# Altium schematic sheet Modules Schematic.SchDoc("Modules Schematic")
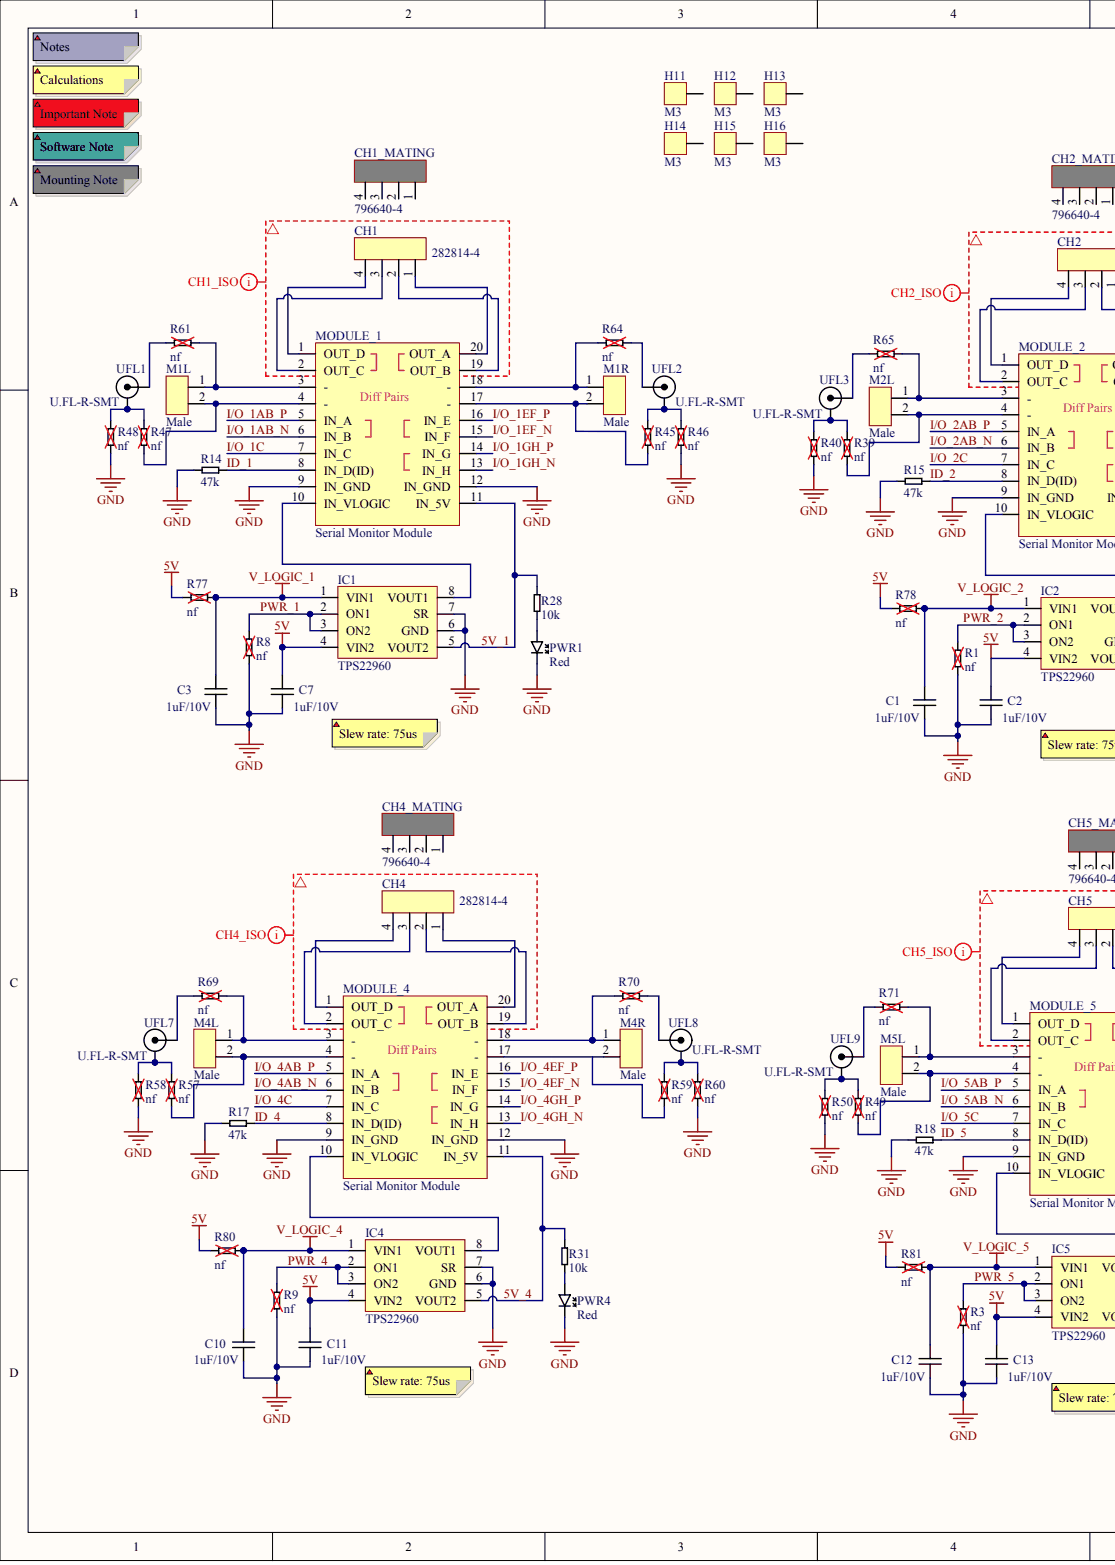
[diagram p0: Modules Schematic.SchDoc, left]
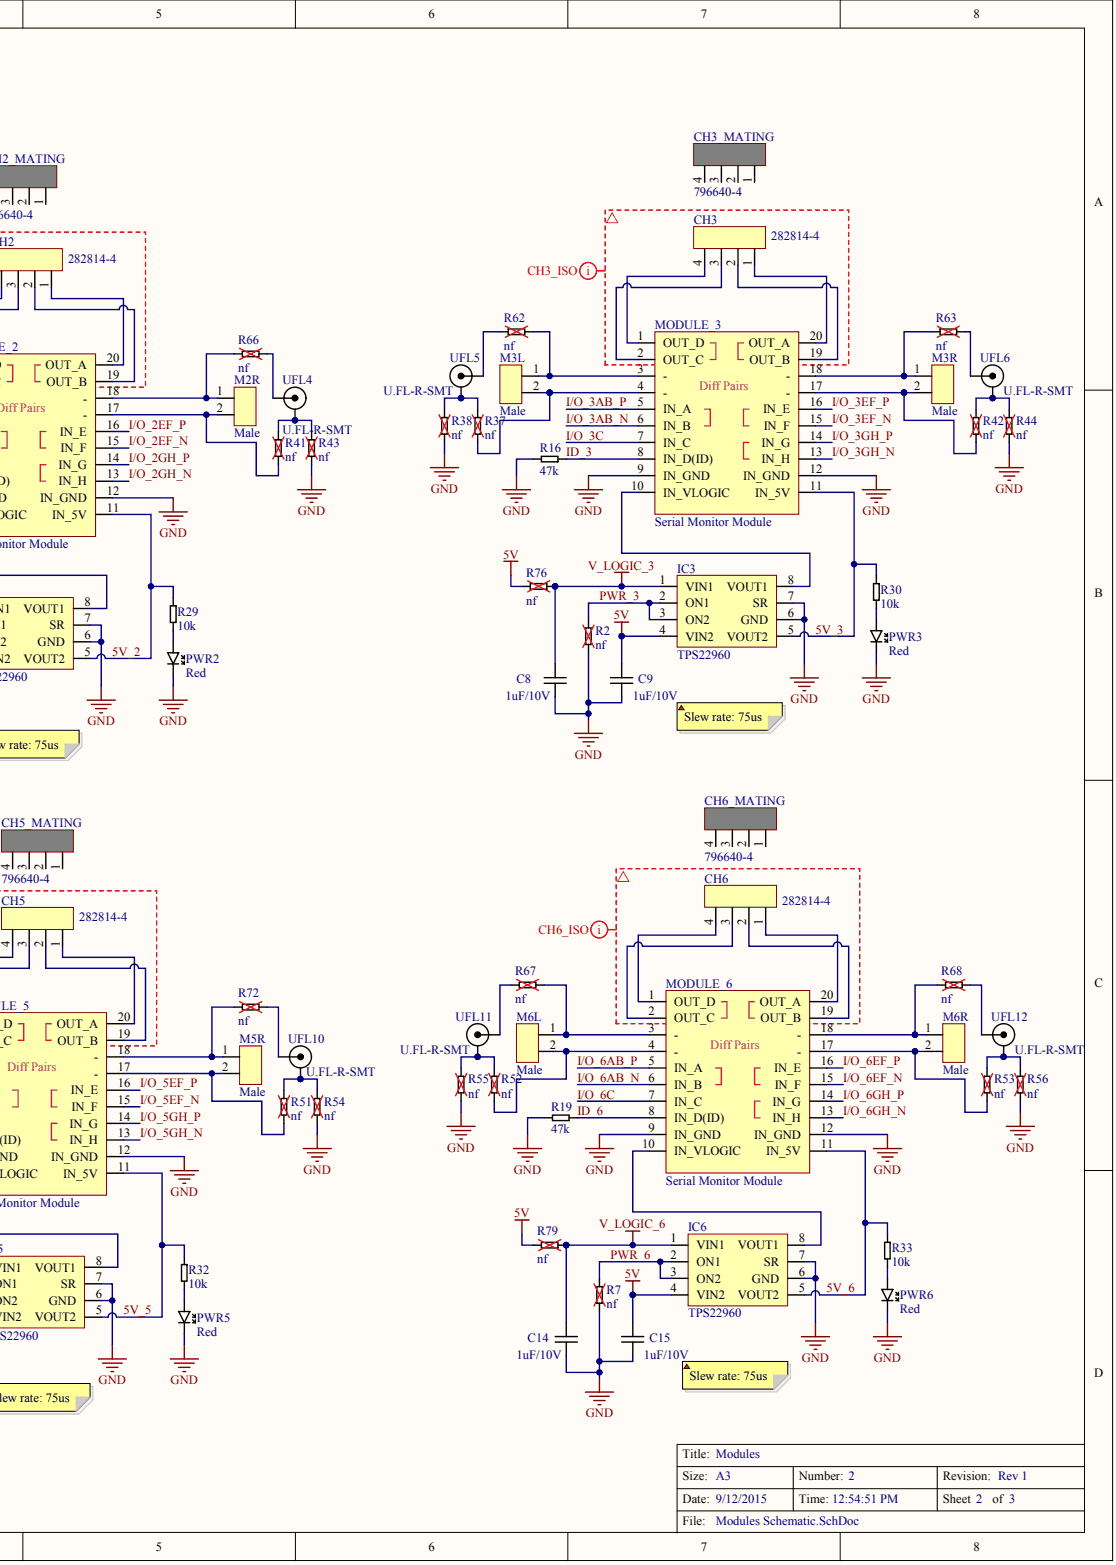
[diagram p1: Modules Schematic.SchDoc, right]

## Parts
C1  value 1uF/10V  [0603]  (hidden comment: Cap_0603_1uF/10V)
C2  value 1uF/10V  [0603]  (hidden comment: Cap_0603_1uF/10V)
C3  value 1uF/10V  [0603]  (hidden comment: Cap_0603_1uF/10V)
C7  value 1uF/10V  [0603]  (hidden comment: Cap_0603_1uF/10V)
C8  value 1uF/10V  [0603]  (hidden comment: Cap_0603_1uF/10V)
C9  value 1uF/10V  [0603]  (hidden comment: Cap_0603_1uF/10V)
C10  value 1uF/10V  [0603]  (hidden comment: Cap_0603_1uF/10V)
C11  value 1uF/10V  [0603]  (hidden comment: Cap_0603_1uF/10V)
C12  value 1uF/10V  [0603]  (hidden comment: Cap_0603_1uF/10V)
C13  value 1uF/10V  [0603]  (hidden comment: Cap_0603_1uF/10V)
C14  value 1uF/10V  [0603]  (hidden comment: Cap_0603_1uF/10V)
C15  value 1uF/10V  [0603]  (hidden comment: Cap_0603_1uF/10V)
CH1  value 282814-4  Part No.: 282814-4  (hidden comment: Terminal Block 1x4 5.08mm)
CH1_MATING  value 796640-4  Part No.: 796640-4  (hidden comment: Terminal Block 1x4 5mm Mating Connector)
CH2  value 282814-4  Part No.: 282814-4  (hidden comment: Terminal Block 1x4 5.08mm)
CH2_MATING  value 796640-4  Part No.: 796640-4  (hidden comment: Terminal Block 1x4 5mm Mating Connector)
CH3  value 282814-4  Part No.: 282814-4  (hidden comment: Terminal Block 1x4 5.08mm)
CH3_MATING  value 796640-4  Part No.: 796640-4  (hidden comment: Terminal Block 1x4 5mm Mating Connector)
CH4  value 282814-4  Part No.: 282814-4  (hidden comment: Terminal Block 1x4 5.08mm)
CH4_MATING  value 796640-4  Part No.: 796640-4  (hidden comment: Terminal Block 1x4 5mm Mating Connector)
CH5  value 282814-4  Part No.: 282814-4  (hidden comment: Terminal Block 1x4 5.08mm)
CH5_MATING  value 796640-4  Part No.: 796640-4  (hidden comment: Terminal Block 1x4 5mm Mating Connector)
CH6  value 282814-4  Part No.: 282814-4  (hidden comment: Terminal Block 1x4 5.08mm)
CH6_MATING  value 796640-4  Part No.: 796640-4  (hidden comment: Terminal Block 1x4 5mm Mating Connector)
H11  value M3  (hidden comment: M3_SCREWHOLE)
H12  value M3  (hidden comment: M3_SCREWHOLE)
H13  value M3  (hidden comment: M3_SCREWHOLE)
H14  value M3  (hidden comment: M3_SCREWHOLE)
H15  value M3  (hidden comment: M3_SCREWHOLE)
H16  value M3  (hidden comment: M3_SCREWHOLE)
IC1  TPS22960  [SOT-23-8]
IC2  TPS22960  [SOT-23-8]
IC3  TPS22960  [SOT-23-8]
IC4  TPS22960  [SOT-23-8]
IC5  TPS22960  [SOT-23-8]
IC6  TPS22960  [SOT-23-8]
M1L  value Male  (hidden comment: Connector 1x2)
M1R  value Male  (hidden comment: Connector 1x2)
M2L  value Male  (hidden comment: Connector 1x2)
M2R  value Male  (hidden comment: Connector 1x2)
M3L  value Male  (hidden comment: Connector 1x2)
M3R  value Male  (hidden comment: Connector 1x2)
M4L  value Male  (hidden comment: Connector 1x2)
M4R  value Male  (hidden comment: Connector 1x2)
M5L  value Male  (hidden comment: Connector 1x2)
M5R  value Male  (hidden comment: Connector 1x2)
M6L  value Male  (hidden comment: Connector 1x2)
M6R  value Male  (hidden comment: Connector 1x2)
MODULE_1  Serial Monitor Module
MODULE_2  Serial Monitor Module
MODULE_3  Serial Monitor Module
MODULE_4  Serial Monitor Module
MODULE_5  Serial Monitor Module
MODULE_6  Serial Monitor Module
PWR1  value Red  (hidden comment: LED_0603_Red)
PWR2  value Red  (hidden comment: LED_0603_Red)
PWR3  value Red  (hidden comment: LED_0603_Red)
PWR4  value Red  (hidden comment: LED_0603_Red)
PWR5  value Red  (hidden comment: LED_0603_Red)
PWR6  value Red  (hidden comment: LED_0603_Red)
R1  value nf  [0603]  (hidden comment: Res_0603_nf)
R2  value nf  [0603]  (hidden comment: Res_0603_nf)
R3  value nf  [0603]  (hidden comment: Res_0603_nf)
R7  value nf  [0603]  (hidden comment: Res_0603_nf)
R8  value nf  [0603]  (hidden comment: Res_0603_nf)
R9  value nf  [0603]  (hidden comment: Res_0603_nf)
R14  value 47k  [0603]  (hidden comment: Res_0603_47k)
R15  value 47k  [0603]  (hidden comment: Res_0603_47k)
R16  value 47k  [0603]  (hidden comment: Res_0603_47k)
R17  value 47k  [0603]  (hidden comment: Res_0603_47k)
R18  value 47k  [0603]  (hidden comment: Res_0603_47k)
R19  value 47k  [0603]  (hidden comment: Res_0603_47k)
R28  value 10k  [0603]  (hidden comment: Res_0603_10k)
R29  value 10k  [0603]  (hidden comment: Res_0603_10k)
R30  value 10k  [0603]  (hidden comment: Res_0603_10k)
R31  value 10k  [0603]  (hidden comment: Res_0603_10k)
R32  value 10k  [0603]  (hidden comment: Res_0603_10k)
R33  value 10k  [0603]  (hidden comment: Res_0603_10k)
R37  value nf  [0603]  (hidden comment: Res_0603_nf)
R38  value nf  [0603]  (hidden comment: Res_0603_nf)
R39  value nf  [0603]  (hidden comment: Res_0603_nf)
R40  value nf  [0603]  (hidden comment: Res_0603_nf)
R41  value nf  [0603]  (hidden comment: Res_0603_nf)
R42  value nf  [0603]  (hidden comment: Res_0603_nf)
R43  value nf  [0603]  (hidden comment: Res_0603_nf)
R44  value nf  [0603]  (hidden comment: Res_0603_nf)
R45  value nf  [0603]  (hidden comment: Res_0603_nf)
R46  value nf  [0603]  (hidden comment: Res_0603_nf)
R47  value nf  [0603]  (hidden comment: Res_0603_nf)
R48  value nf  [0603]  (hidden comment: Res_0603_nf)
R49  value nf  [0603]  (hidden comment: Res_0603_nf)
R50  value nf  [0603]  (hidden comment: Res_0603_nf)
R51  value nf  [0603]  (hidden comment: Res_0603_nf)
R52  value nf  [0603]  (hidden comment: Res_0603_nf)
R53  value nf  [0603]  (hidden comment: Res_0603_nf)
R54  value nf  [0603]  (hidden comment: Res_0603_nf)
R55  value nf  [0603]  (hidden comment: Res_0603_nf)
R56  value nf  [0603]  (hidden comment: Res_0603_nf)
R57  value nf  [0603]  (hidden comment: Res_0603_nf)
R58  value nf  [0603]  (hidden comment: Res_0603_nf)
R59  value nf  [0603]  (hidden comment: Res_0603_nf)
R60  value nf  [0603]  (hidden comment: Res_0603_nf)
R61  value nf  [0603]  (hidden comment: Res_0603_nf)
R62  value nf  [0603]  (hidden comment: Res_0603_nf)
R63  value nf  [0603]  (hidden comment: Res_0603_nf)
R64  value nf  [0603]  (hidden comment: Res_0603_nf)
R65  value nf  [0603]  (hidden comment: Res_0603_nf)
R66  value nf  [0603]  (hidden comment: Res_0603_nf)
R67  value nf  [0603]  (hidden comment: Res_0603_nf)
R68  value nf  [0603]  (hidden comment: Res_0603_nf)
R69  value nf  [0603]  (hidden comment: Res_0603_nf)
R70  value nf  [0603]  (hidden comment: Res_0603_nf)
R71  value nf  [0603]  (hidden comment: Res_0603_nf)
R72  value nf  [0603]  (hidden comment: Res_0603_nf)
R76  value nf  [0603]  (hidden comment: Res_0603_nf)
R77  value nf  [0603]  (hidden comment: Res_0603_nf)
R78  value nf  [0603]  (hidden comment: Res_0603_nf)
R79  value nf  [0603]  (hidden comment: Res_0603_nf)
R80  value nf  [0603]  (hidden comment: Res_0603_nf)
R81  value nf  [0603]  (hidden comment: Res_0603_nf)
UFL1  U.FL-R-SMT
UFL2  U.FL-R-SMT
UFL3  U.FL-R-SMT
UFL4  U.FL-R-SMT
UFL5  U.FL-R-SMT
UFL6  U.FL-R-SMT
UFL7  U.FL-R-SMT
UFL8  U.FL-R-SMT
UFL9  U.FL-R-SMT
UFL10  U.FL-R-SMT
UFL11  U.FL-R-SMT
UFL12  U.FL-R-SMT

## Nets
5V: C2.2 C7.2 C9.2 C11.2 C13.2 C15.2 IC1.4(VIN2) IC2.4(VIN2) IC3.4(VIN2) IC4.4(VIN2) IC5.4(VIN2) IC6.4(VIN2) R76.1 R77.1 R78.1 R79.1 R80.1 R81.1
GND: C1.1 C2.1 C3.1 C7.1 C8.1 C9.1 C10.1 C11.1 C12.1 C13.1 C14.1 C15.1 IC1.6(GND) IC1.7(SR) IC2.6(GND) IC2.7(SR) IC3.6(GND) IC3.7(SR) IC4.6(GND) IC4.7(SR) IC5.6(GND) IC5.7(SR) IC6.6(GND) IC6.7(SR) MODULE_1.9(IN_GND) MODULE_1.12(IN_GND) MODULE_2.9(IN_GND) MODULE_2.12(IN_GND) MODULE_3.9(IN_GND) MODULE_3.12(IN_GND) MODULE_4.9(IN_GND) MODULE_4.12(IN_GND) MODULE_5.9(IN_GND) MODULE_5.12(IN_GND) MODULE_6.9(IN_GND) MODULE_6.12(IN_GND) PWR1.CATHODE PWR2.CATHODE PWR3.CATHODE PWR4.CATHODE PWR5.CATHODE PWR6.CATHODE R1.2 R2.2 R3.2 R7.2 R8.2 R9.2 R14.1 R15.1 R16.1 R17.1 R18.1 R19.1 R38.1 R40.1 R43.1 R44.1 R46.1 R48.1 R50.1 R54.1 R55.1 R56.1 R58.1 R60.1
V_LOGIC_1: C3.2 IC1.1(VIN1) R77.2
V_LOGIC_2: C1.2 IC2.1(VIN1) R78.2
V_LOGIC_3: C8.2 IC3.1(VIN1) R76.2
V_LOGIC_4: C10.2 IC4.1(VIN1) R80.2
V_LOGIC_5: C12.2 IC5.1(VIN1) R81.2
V_LOGIC_6: C14.2 IC6.1(VIN1) R79.2
5V_1: IC1.5(VOUT2) MODULE_1.11(IN_5V) R28.2
5V_2: IC2.5(VOUT2) MODULE_2.11(IN_5V) R29.2
5V_3: IC3.5(VOUT2) MODULE_3.11(IN_5V) R30.2
5V_4: IC4.5(VOUT2) MODULE_4.11(IN_5V) R31.2
5V_5: IC5.5(VOUT2) MODULE_5.11(IN_5V) R32.2
5V_6: IC6.5(VOUT2) MODULE_6.11(IN_5V) R33.2
I/O_1AB_N: MODULE_1.6(IN_B)
I/O_1AB_P: MODULE_1.5(IN_A)
I/O_1C: MODULE_1.7(IN_C)
I/O_1EF_N: MODULE_1.15(IN_F)
I/O_1EF_P: MODULE_1.16(IN_E)
I/O_1GH_N: MODULE_1.13(IN_H)
I/O_1GH_P: MODULE_1.14(IN_G)
I/O_2AB_N: MODULE_2.6(IN_B)
I/O_2AB_P: MODULE_2.5(IN_A)
I/O_2C: MODULE_2.7(IN_C)
I/O_2EF_N: MODULE_2.15(IN_F)
I/O_2EF_P: MODULE_2.16(IN_E)
I/O_2GH_N: MODULE_2.13(IN_H)
I/O_2GH_P: MODULE_2.14(IN_G)
I/O_3AB_N: MODULE_3.6(IN_B)
I/O_3AB_P: MODULE_3.5(IN_A)
I/O_3C: MODULE_3.7(IN_C)
I/O_3EF_N: MODULE_3.15(IN_F)
I/O_3EF_P: MODULE_3.16(IN_E)
I/O_3GH_N: MODULE_3.13(IN_H)
I/O_3GH_P: MODULE_3.14(IN_G)
I/O_4AB_N: MODULE_4.6(IN_B)
I/O_4AB_P: MODULE_4.5(IN_A)
I/O_4C: MODULE_4.7(IN_C)
I/O_4EF_N: MODULE_4.15(IN_F)
I/O_4EF_P: MODULE_4.16(IN_E)
I/O_4GH_N: MODULE_4.13(IN_H)
I/O_4GH_P: MODULE_4.14(IN_G)
I/O_5AB_N: MODULE_5.6(IN_B)
I/O_5AB_P: MODULE_5.5(IN_A)
I/O_5C: MODULE_5.7(IN_C)
I/O_5EF_N: MODULE_5.15(IN_F)
I/O_5EF_P: MODULE_5.16(IN_E)
I/O_5GH_N: MODULE_5.13(IN_H)
I/O_5GH_P: MODULE_5.14(IN_G)
I/O_6AB_N: MODULE_6.6(IN_B)
I/O_6AB_P: MODULE_6.5(IN_A)
I/O_6C: MODULE_6.7(IN_C)
I/O_6EF_N: MODULE_6.15(IN_F)
I/O_6EF_P: MODULE_6.16(IN_E)
I/O_6GH_N: MODULE_6.13(IN_H)
I/O_6GH_P: MODULE_6.14(IN_G)
ID_1: MODULE_1.8(IN_D(ID)) R14.2
ID_2: MODULE_2.8(IN_D(ID)) R15.2
ID_3: MODULE_3.8(IN_D(ID)) R16.2
ID_4: MODULE_4.8(IN_D(ID)) R17.2
ID_5: MODULE_5.8(IN_D(ID)) R18.2
ID_6: MODULE_6.8(IN_D(ID)) R19.2
PWR_1: IC1.2(ON1) IC1.3(ON2) R8.1
PWR_2: IC2.2(ON1) IC2.3(ON2) R1.1
PWR_3: IC3.2(ON1) IC3.3(ON2) R2.1
PWR_4: IC4.2(ON1) IC4.3(ON2) R9.1
PWR_5: IC5.2(ON1) IC5.3(ON2) R3.1
PWR_6: IC6.2(ON1) IC6.3(ON2) R7.1
NetCH1_1: CH1.1 MODULE_1.20(OUT_A)
NetCH1_2: CH1.2 MODULE_1.19(OUT_B)
NetCH1_3: CH1.3 MODULE_1.2(OUT_C)
NetCH1_4: CH1.4 MODULE_1.1(OUT_D)
NetCH2_1: CH2.1 MODULE_2.20(OUT_A)
NetCH2_2: CH2.2 MODULE_2.19(OUT_B)
NetCH2_3: CH2.3 MODULE_2.2(OUT_C)
NetCH2_4: CH2.4 MODULE_2.1(OUT_D)
NetCH3_1: CH3.1 MODULE_3.20(OUT_A)
NetCH3_2: CH3.2 MODULE_3.19(OUT_B)
NetCH3_3: CH3.3 MODULE_3.2(OUT_C)
NetCH3_4: CH3.4 MODULE_3.1(OUT_D)
NetCH4_1: CH4.1 MODULE_4.20(OUT_A)
NetCH4_2: CH4.2 MODULE_4.19(OUT_B)
NetCH4_3: CH4.3 MODULE_4.2(OUT_C)
NetCH4_4: CH4.4 MODULE_4.1(OUT_D)
NetCH5_1: CH5.1 MODULE_5.20(OUT_A)
NetCH5_2: CH5.2 MODULE_5.19(OUT_B)
NetCH5_3: CH5.3 MODULE_5.2(OUT_C)
NetCH5_4: CH5.4 MODULE_5.1(OUT_D)
NetCH6_1: CH6.1 MODULE_6.20(OUT_A)
NetCH6_2: CH6.2 MODULE_6.19(OUT_B)
NetCH6_3: CH6.3 MODULE_6.2(OUT_C)
NetCH6_4: CH6.4 MODULE_6.1(OUT_D)
NetIC1_8: IC1.8(VOUT1) MODULE_1.10(IN_VLOGIC)
NetIC2_8: IC2.8(VOUT1) MODULE_2.10(IN_VLOGIC)
NetIC3_8: IC3.8(VOUT1) MODULE_3.10(IN_VLOGIC)
NetIC4_8: IC4.8(VOUT1) MODULE_4.10(IN_VLOGIC)
NetIC5_8: IC5.8(VOUT1) MODULE_5.10(IN_VLOGIC)
NetIC6_8: IC6.8(VOUT1) MODULE_6.10(IN_VLOGIC)
NetM1L_1: M1L.1 MODULE_1.3(-) R61.1
NetM1L_2: M1L.2 MODULE_1.4(-) R47.1
NetM1R_1: M1R.1 MODULE_1.18(-) R64.2
NetM1R_2: M1R.2 MODULE_1.17(-) R45.1
NetM2L_1: M2L.1 MODULE_2.3(-) R65.1
NetM2L_2: M2L.2 MODULE_2.4(-) R39.1
NetM2R_1: M2R.1 MODULE_2.18(-) R66.2
NetM2R_2: M2R.2 MODULE_2.17(-) R41.1
NetM3L_1: M3L.1 MODULE_3.3(-) R62.1
NetM3L_2: M3L.2 MODULE_3.4(-) R37.1
NetM3R_1: M3R.1 MODULE_3.18(-) R63.2
NetM3R_2: M3R.2 MODULE_3.17(-) R42.1
NetM4L_1: M4L.1 MODULE_4.3(-) R69.1
NetM4L_2: M4L.2 MODULE_4.4(-) R57.1
NetM4R_1: M4R.1 MODULE_4.18(-) R70.2
NetM4R_2: M4R.2 MODULE_4.17(-) R59.1
NetM5L_1: M5L.1 MODULE_5.3(-) R71.1
NetM5L_2: M5L.2 MODULE_5.4(-) R49.1
NetM5R_1: M5R.1 MODULE_5.18(-) R72.2
NetM5R_2: M5R.2 MODULE_5.17(-) R51.1
NetM6L_1: M6L.1 MODULE_6.3(-) R67.1
NetM6L_2: M6L.2 MODULE_6.4(-) R52.1
NetM6R_1: M6R.1 MODULE_6.18(-) R68.2
NetM6R_2: M6R.2 MODULE_6.17(-) R53.1
NetPWR1_ANODE: PWR1.ANODE R28.1
NetPWR2_ANODE: PWR2.ANODE R29.1
NetPWR3_ANODE: PWR3.ANODE R30.1
NetPWR4_ANODE: PWR4.ANODE R31.1
NetPWR5_ANODE: PWR5.ANODE R32.1
NetPWR6_ANODE: PWR6.ANODE R33.1
NetR37_2: R37.2 R38.2 UFL5.2
NetR39_2: R39.2 R40.2 UFL3.2
NetR41_2: R41.2 R43.2 UFL4.2
NetR42_2: R42.2 R44.2 UFL6.2
NetR45_2: R45.2 R46.2 UFL2.2
NetR47_2: R47.2 R48.2 UFL1.2
NetR49_2: R49.2 R50.2 UFL9.2
NetR51_2: R51.2 R54.2 UFL10.2
NetR52_2: R52.2 R55.2 UFL11.2
NetR53_2: R53.2 R56.2 UFL12.2
NetR57_2: R57.2 R58.2 UFL7.2
NetR59_2: R59.2 R60.2 UFL8.2
NetR61_2: R61.2 UFL1.1
NetR62_2: R62.2 UFL5.1
NetR63_1: R63.1 UFL6.1
NetR64_1: R64.1 UFL2.1
NetR65_2: R65.2 UFL3.1
NetR66_1: R66.1 UFL4.1
NetR67_2: R67.2 UFL11.1
NetR68_1: R68.1 UFL12.1
NetR69_2: R69.2 UFL7.1
NetR70_1: R70.1 UFL8.1
NetR71_2: R71.2 UFL9.1
NetR72_1: R72.1 UFL10.1
N00171:
N00172:
N00173:
N00174:
N00175:
N00176:

Not connected: CH1_MATING 1 2 3 4; CH2_MATING 1 2 3 4; CH3_MATING 1 2 3 4; CH4_MATING 1 2 3 4; CH5_MATING 1 2 3 4; CH6_MATING 1 2 3 4; H11 HOLE; H12 HOLE; H13 HOLE; H14 HOLE; H15 HOLE; H16 HOLE

## Library data of the parts
C1-C3 C7-C15 = (no symbol name): Part No.: C1608X5R1A105K080AC; Manufacturer: TDK; Mouser: 810-C1608X5R1A105K; Price SEK (No VAT): 1; DigiKey: 445-1321-1-ND
CH1-CH6 = (no symbol name): Manufacturer: TE Connectivity; Mating Connector: 796634-4; Mouser: 571-282814-4; RS: *; DigiKey: A98067-ND; Elfa: *; Price SEK (No VAT): 8
CH1_MATING CH2_MATING CH3_MATING CH4_MATING CH5_MATING CH6_MATING = (no symbol name): Manufacturer: TE Connectivity; RS: 710-0438 (5-pack); Mouser: 571-7966404; DigiKey: A98243-ND; Elfa: *; Price SEK (No VAT): 25; Farnell: 4540086
H11-H13 = (no symbol name): Value: M3
IC1-IC6 = (no symbol name): Part No.: TPS22960DCNR; Manufacturer: TI; DigiKey: 296-24545-1-ND; Price SEK (No VAT): 10; Mouser: 595-TPS22960DCNR; Value: TPS22960
M1L = (no symbol name): Value: Male
PWR1-PWR6 = (no symbol name): Part No.: APT1608SRCPRV; Manufacturer: Kingbright; RS: 654-5420; DigiKey: 754-1122-1-ND; Price SEK (No VAT): 1; Mouser: 604-APT1608SRCPRV
R14-R19 = (no symbol name): Part No.: CRCW060347K0FKEA; Manufacturer: Vishay; Price SEK (No VAT): 0,1; Mouser: 71-CRCW0603-47K-E3; DigiKey: 541-47.0KHCT-ND
R28-R33 = (no symbol name): Part No.: CRCW060310K0FKEA; Manufacturer: Vishay; Price SEK (No VAT): 0,1; Mouser: 71-CRCW0603-10K-E3; DigiKey: 541-10.0KHCT-ND
R62 R63 R65 R66 = (no symbol name): Value: nf; Price SEK (No VAT): 0
UFL1-UFL12 = (no symbol name): Part No.: Hirose Electric Co Ltd; RS: U.FL-R-SMT-1(10); Manufacturer: Hirose Electric Co Ltd; Mouser: 798-U.FL-R-SMT01; DigiKey: H9161-ND; Farnell: 3908021; Price SEK (No VAT): 11; Value: U.FL-R-SMT
H14-H16 M1R M2L M2R M3L M3R M4L M4R M5L M5R M6L M6R MODULE_1-MODULE_6 R1-R3 R7-R9 R37-R61 R64 R67-R72 R76-R81 = (no symbol name)
  MODULE_1-MODULE_6: Value: Serial Monitor Module
  R1-R3 R7-R9 R37-R61 R64 R67-R72 R76-R81: Price SEK (No VAT): 0
